# Altium schematic sheet Main.SchDoc(Main)
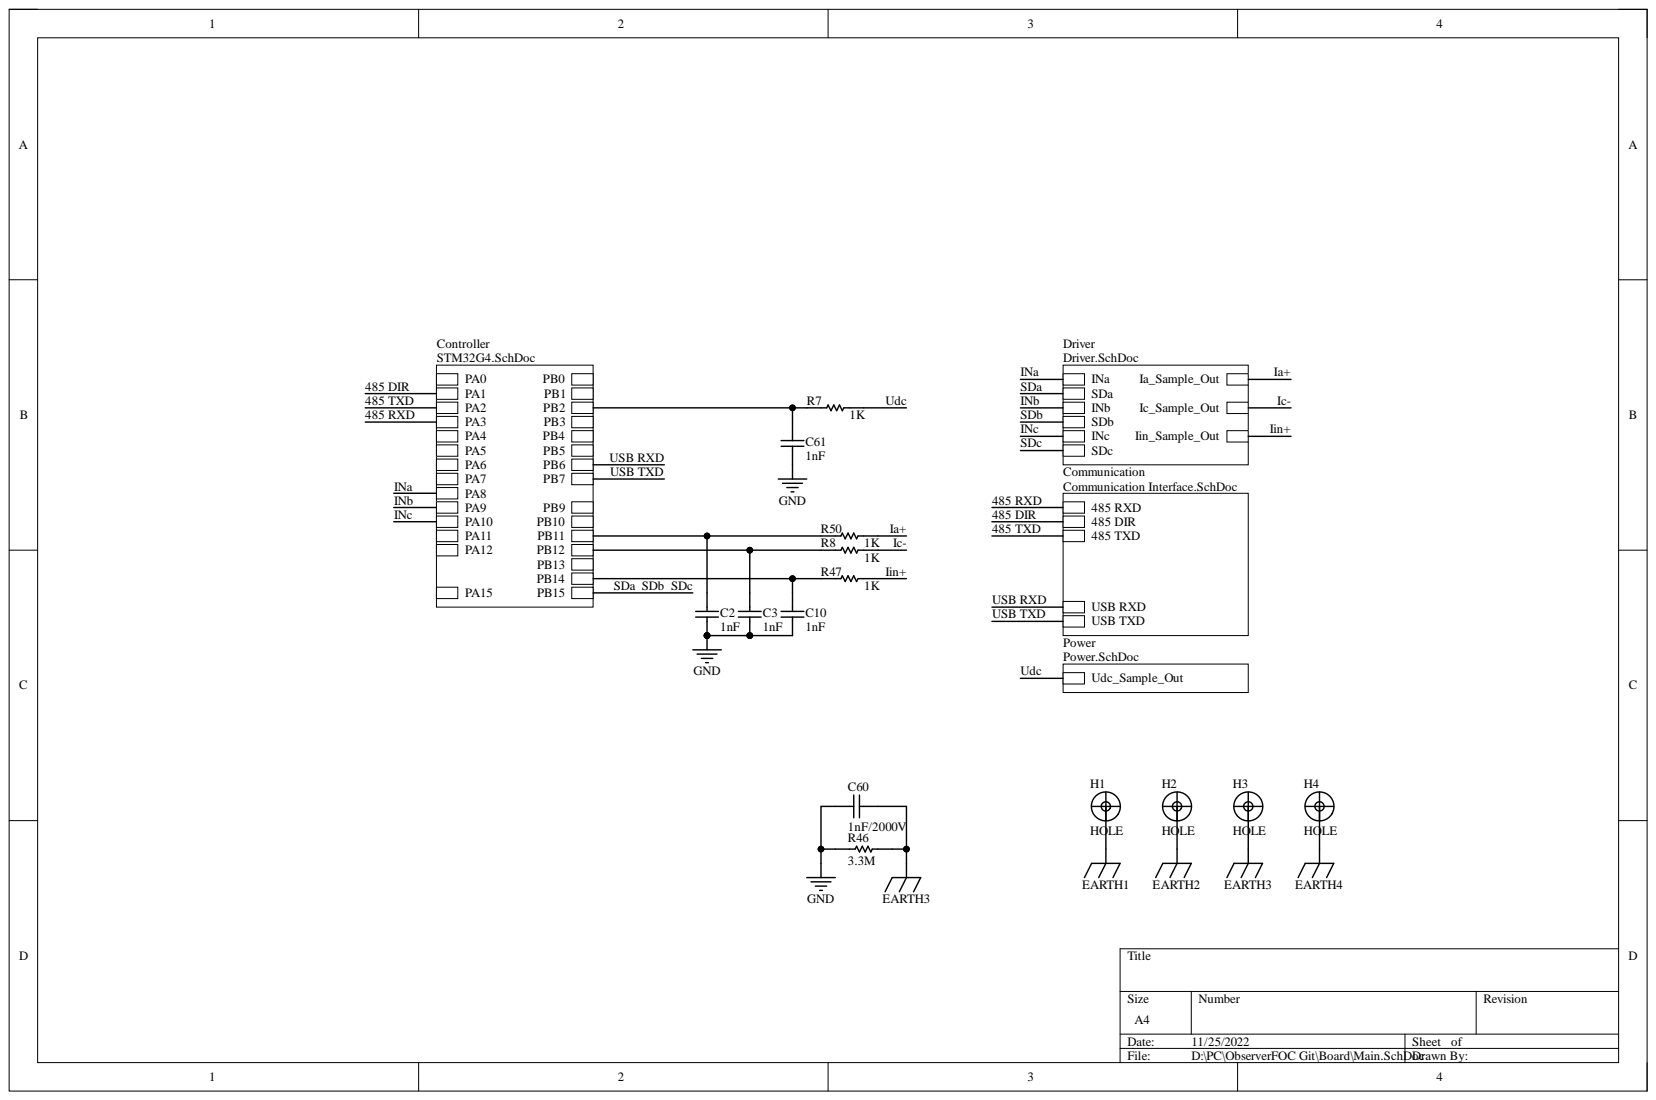
[diagram p0: Main.SchDoc]

## Parts
C2  1nF  [C0402]
C3  1nF  [C0402]
C10  1nF  [C0402]
C60  1nF/2000V  [C1206]
C61  1nF  [C0402]
H1  HOLE  [定位孔 Φ3 1]
H2  HOLE  [定位孔 Φ3 1]
H3  HOLE  [定位孔 Φ3 1]
H4  HOLE  [定位孔 Φ3 1]
R7  1K  [R0402]
R8  1K  [R0402]
R46  3.3M  [R1206]
R47  1K  [R0402]
R50  1K  [R0402]

## Nets
EARTH1: H1.1
EARTH2: H2.1
EARTH3: C60.2 H3.1 R46.1
EARTH4: H4.1
GND: C2.2 C3.2 C10.2 C60.1 C61.2 R46.2
Ia+: R50.1
Ic-: R8.1
Iin+: R47.1
Udc: R7.1
NetC2_1: C2.1 R50.2
NetC3_1: C3.1 R8.2
NetC10_1: C10.1 R47.2
NetC61_1: C61.1 R7.2
485 DIR:
485 RXD:
485 TXD:
INa:
INb:
INc:
PA0:
PA4:
PA5:
PA6:
PA7:
PA11:
PA12:
PA15:
PB0:
PB1:
PB3:
PB4:
PB5:
PB9:
PB10:
PB13:
SDa:
USB RXD:
USB TXD:

## Library data of the parts
C2 C3 C10 C60 C61 = Cap: Component Kind: Standard; Library Name: Cap.IntLib
R7 R8 R46 R47 R50 = RES: Component Kind: Standard; Library Name: Res.IntLib
H1-H4 = HOLE: Component Kind: Standard; Library Name: mylib.IntLib
